# Altium schematic sheet 102-002-00142-00,K12温湿度传感器V1.0原理图.SchDoc
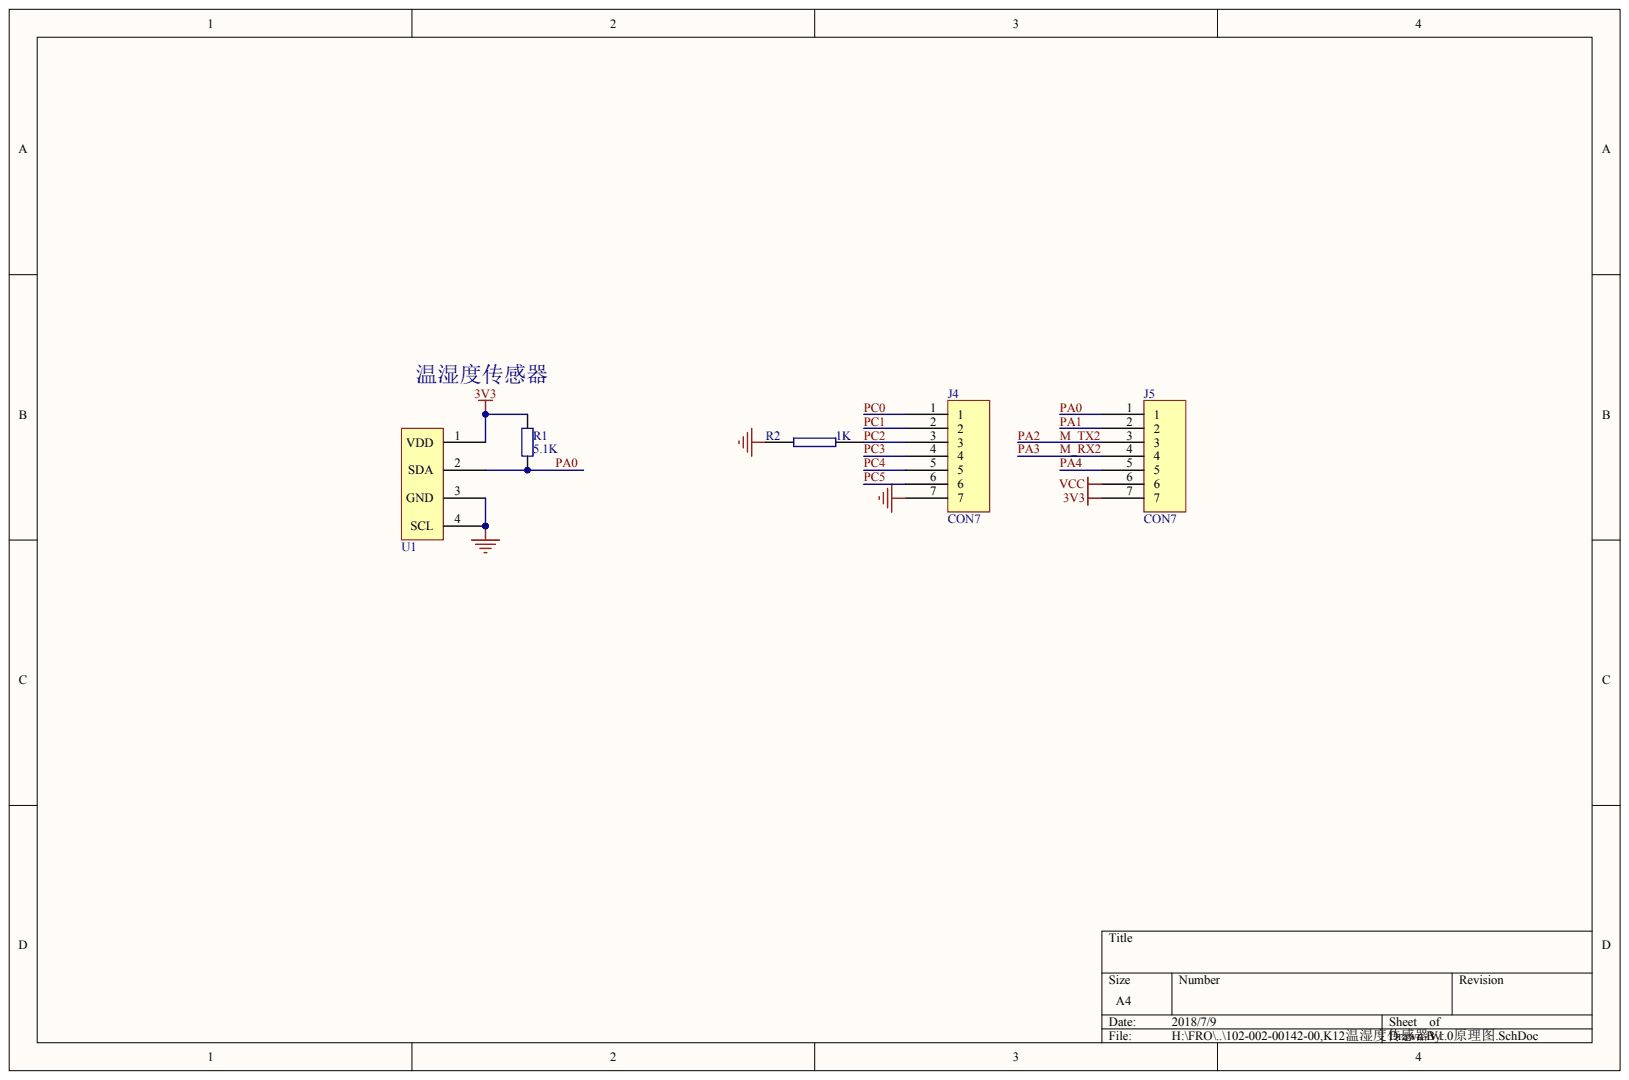
[diagram p0: 102-002-00142-00,K12温湿度传感器V1.0原理图.SchDoc]

## Parts
J4  CON7
J5  CON7
R1  5.1K
R2  1K
U1  AM2321  (value not drawn)

## Nets
3V3: J5.7 R1.1 U1.1(VDD)
GND: J4.7 R2.1 U1.3(GND) U1.4(SCL)
VCC: J5.6
M_RX2: J5.4
M_TX2: J5.3
PA0: J5.1 R1.2 U1.2(SDA)
PA1: J5.2
PA4: J5.5
PC0: J4.1
PC1: J4.2
PC2: J4.3 R2.2
PC3: J4.4
PC4: J4.5
PC5: J4.6

## Library data of the parts
R1 = (no symbol name): wuliaoguige: 0805/5.1kΩ/F; wuliaobianma: 103-001-00512-01; wuliaomingchen: 贴片电阻
R2 = (no symbol name): wuliaobianma: 103-001-00102-01; wuliaoguige: 0805/1KΩ/F; wuliaomingchen: 贴片电阻
U1 = (no symbol name): wuliaoguige: 40p/2.0mm; wuliaomingchen: 温湿度传感器; wuliaobianma: 199-008-00012-00
